# Altium schematic sheet POWER.SchDoc(POWER)
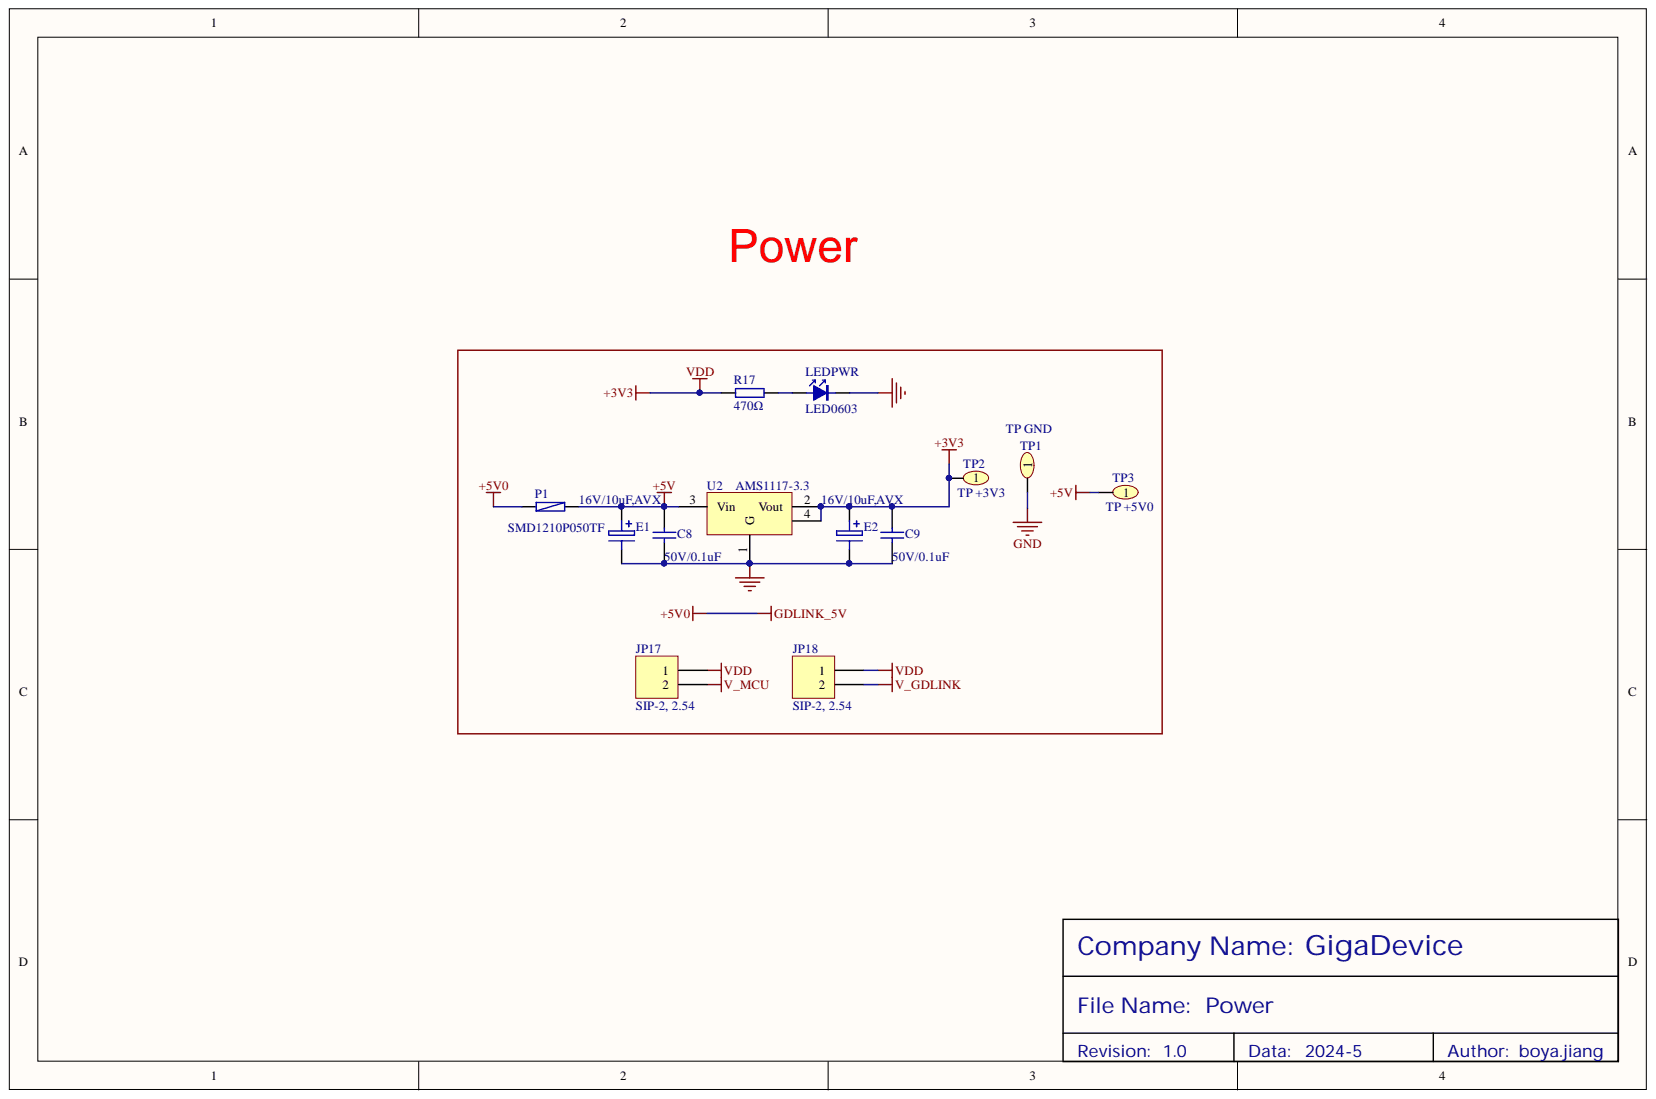
[diagram p0: POWER.SchDoc]

## Parts
C8  50V/0.1uF  [0603-C]
C9  50V/0.1uF  [0603-C]
E1  16V/10uF,AVX  [SM/C-A-3216]
E2  16V/10uF,AVX  [SM/C-A-3216]
JP17  SIP-2, 2.54  [SIP-2]
JP18  SIP-2, 2.54  [SIP-2]
LEDPWR  LED0603  [0603-LED-Green]
P1  SMD1210P050TF  [1210_R]
R17  470Ω  [0603-R]
TP1  TP GND  [SIP-1]
TP2  TP +3V3  [SIP-1]
TP3  TP +5V0  [SIP-1]
U2  AMS1117-3.3  [SOT-223]

## Nets
+3V3: C9.2 E2.1 JP17.1 JP18.1 R17.1 TP2.1 U2.2(Vout) U2.4
+5V: C8.2 E1.1 P1.2 TP3.1 U2.3(Vin)
+5V0: P1.1
GND: C8.1 C9.1 E1.2 E2.2 LEDPWR.K TP1.1 U2.1(G)
V_GDLINK: JP18.2
V_MCU: JP17.2
NetLEDPWR_A: LEDPWR.A R17.2

## Library data of the parts
C8 C9 = 50V/0.1uF: 表贴电容; Component Kind: Standard; Library Name: KLTC-电容.SchLib
E1 E2 = 16V/10uF,AVX: 表贴钽电容; Component Kind: Standard; Library Name: KLTC-电容.SchLib
JP17 JP18 = HEADER 2_1: 单排插针; Component Kind: Standard; Library Name: KLTC-按键及接插件.SchLib
LEDPWR = LED0603: 表贴LED发光管; Component Kind: Standard; Library Name: xufei.SchLib
P1 = SMD1210P005TF: 表贴PTC; Component Kind: Standard; Library Name: KLTC-保护元件.SchLib
R17 = 470Ω: 表贴电阻; Component Kind: Standard; Library Name: KLTC-电阻.SchLib
TP1-TP3 = HEADER 1: 接点; Component Kind: Standard; Library Name: KLTC-按键及接插件.SchLib
U2 = LM1117-3.3: 表贴3.3V LDO; Component Kind: Standard; Library Name: xufei.SchLib
